# Altium schematic sheet AudioWayDSP-Codec.SchDoc
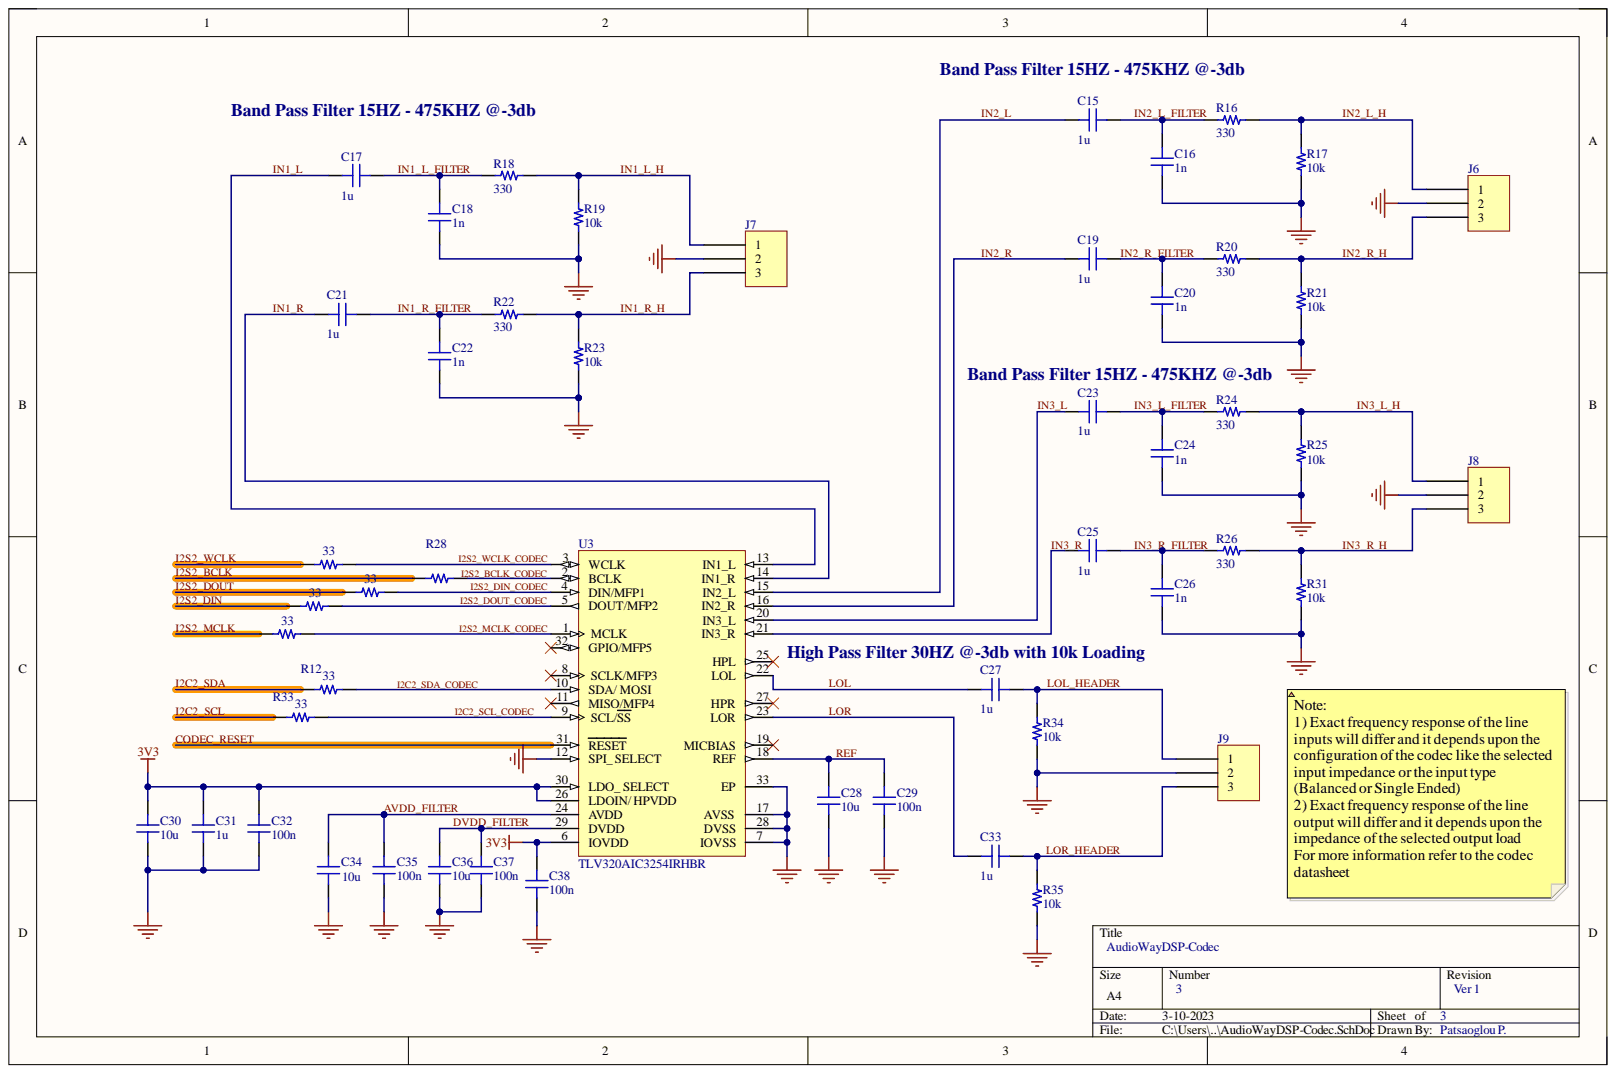
[diagram p0: AudioWayDSP-Codec.SchDoc]

## Parts
C15  1u  [CAP 0603_1608]
C16  1n  [CAP 0402_1005]
C17  1u  [CAP 0603_1608]
C18  1n  [CAP 0402_1005]
C19  1u  [CAP 0603_1608]
C20  1n  [CAP 0402_1005]
C21  1u  [CAP 0603_1608]
C22  1n  [CAP 0402_1005]
C23  1u  [CAP 0603_1608]
C24  1n  [CAP 0402_1005]
C25  1u  [CAP 0603_1608]
C26  1n  [CAP 0402_1005]
C27  1u  [CAP 0603_1608]
C28  10u  [CAP 0603_1608]
C29  100n  [CAP 0402_1005]
C30  10u  [CAP 0603_1608]
C31  1u  [CAP 0603_1608]
C32  100n  [CAP 0402_1005]
C33  1u  [CAP 0603_1608]
C34  10u  [CAP 0603_1608]
C35  100n  [CAP 0402_1005]
C36  10u  [CAP 0603_1608]
C37  100n  [CAP 0402_1005]
C38  100n  [CAP 0402_1005]
J6  B3B-XH-A(LF)(SN)  [JST XH B3B-XH-A]  (value not drawn)
J7  B3B-XH-A(LF)(SN)  [JST XH B3B-XH-A]  (value not drawn)
J8  B3B-XH-A(LF)(SN)  [JST XH B3B-XH-A]  (value not drawn)
J9  B3B-XH-A(LF)(SN)  [JST XH B3B-XH-A]  (value not drawn)
R12  33  [RES 0402_1005]
R16  330  [RES 0402_1005]
R17  10k  [RES 0402_1005]
R18  330  [RES 0402_1005]
R19  10k  [RES 0402_1005]
R20  330  [RES 0402_1005]
R21  10k  [RES 0402_1005]
R22  330  [RES 0402_1005]
R23  10k  [RES 0402_1005]
R24  330  [RES 0402_1005]
R25  10k  [RES 0402_1005]
R26  330  [RES 0402_1005]
R27  33  [RES 0402_1005]
R28  33  [RES 0402_1005]  (value not drawn)
R29  33  [RES 0402_1005]
R30  33  [RES 0402_1005]
R31  10k  [RES 0402_1005]
R32  33  [RES 0402_1005]
R33  33  [RES 0402_1005]
R34  10k  [RES 0402_1005]
R35  10k  [RES 0402_1005]
U3  TLV320AIC3254IRHBR  [RHB0032E_V]

## Nets
3V3: C30.2 C31.2 C32.2 C38.2 U3.6(IOVDD) U3.26(LDOIN/_HPVDD) U3.30(LDO__SELECT)
GND: C16.1 C18.1 C20.1 C22.1 C24.1 C26.1 C28.1 C29.1 C30.1 C31.1 C32.1 C34.1 C35.1 C36.1 C37.1 C38.1 J6.2 J7.2 J8.2 J9.2 R17.1 R19.1 R21.1 R23.1 R25.1 R31.1 R34.1 R35.1 U3.7(IOVSS) U3.12(SPI__SELECT) U3.17(AVSS) U3.28(DVSS) U3.33(EP)
AVDD_FILTER: C34.2 C35.2 U3.24(AVDD)
CODEC_RESET: U3.31(RESET)
DVDD_FILTER: C36.2 C37.2 U3.29(DVDD)
I2C2_SCL: R33.1
I2C2_SCL_CODEC: R33.2 U3.9(SCL/SS)
I2C2_SDA: R12.1
I2C2_SDA_CODEC: R12.2 U3.10(SDA/_MOSI)
I2S2_BCLK: R28.1
I2S2_BCLK_CODEC: R28.2 U3.2(BCLK)
I2S2_DIN: R30.1
I2S2_DIN_CODEC: R29.2 U3.4(DIN/MFP1)
I2S2_DOUT: R29.1
I2S2_DOUT_CODEC: R30.2 U3.5(DOUT/MFP2)
I2S2_MCLK: R32.1
I2S2_MCLK_CODEC: R32.2 U3.1(MCLK)
I2S2_WCLK: R27.1
I2S2_WCLK_CODEC: R27.2 U3.3(WCLK)
IN1_L: C17.2 U3.13(IN1_L)
IN1_L_FILTER: C17.1 C18.2 R18.2
IN1_L_H: J7.1 R18.1 R19.2
IN1_R: C21.2 U3.14(IN1_R)
IN1_R_FILTER: C21.1 C22.2 R22.2
IN1_R_H: J7.3 R22.1 R23.2
IN2_L: C15.2 U3.15(IN2_L)
IN2_L_FILTER: C15.1 C16.2 R16.2
IN2_L_H: J6.1 R16.1 R17.2
IN2_R: C19.2 U3.16(IN2_R)
IN2_R_FILTER: C19.1 C20.2 R20.2
IN2_R_H: J6.3 R20.1 R21.2
IN3_L: C23.2 U3.20(IN3_L)
IN3_L_FILTER: C23.1 C24.2 R24.2
IN3_L_H: J8.1 R24.1 R25.2
IN3_R: C25.2 U3.21(IN3_R)
IN3_R_FILTER: C25.1 C26.2 R26.2
IN3_R_H: J8.3 R26.1 R31.2
LOL: C27.2 U3.22(LOL)
LOL_HEADER: C27.1 J9.1 R34.2
LOR: C33.2 U3.23(LOR)
LOR_HEADER: C33.1 J9.3 R35.2
REF: C28.2 C29.2 U3.18(REF)

Not connected: U3 8(SCLK/MFP3) 11(MISO/MFP4) 19(MICBIAS) 25(HPL) 27(HPR) 32(GPIO/MFP5)

## Library data of the parts
C15 C17 C19 C21 C23 C25 C27 C31 C33 = 06035D105KAT2A: CAP CER 1UF 50V X5R 0603; manufacturer: AVX Corporation; Capacitance: 1µF; Database Table Name: Capacitors - Ceramic - 0603; Tolerance: ±10%; Applications: General Purpose; Component Kind: Standard; componentlink1description: Datasheet; componentlink1url: http://datasheets.avx.com/cx5r.pdf; componentlink2description: DigiKey Link; componentlink2url: http://digikey.com/product-detail/en/avx-corporation/06035D105KAT2A/478-10067-1-ND/6564289; Device Package: 0603 (1608 Metric); lastupdated: 02-Sep-20 1:03:26 AM; Library Name: Celestial Altium Library - altium_library.DbLib; Lifecycle Status: Active; Minimum Order: 1; Mounting Type: Surface Mount, MLCC; Operating Temperature: -55°C ~ 85°C; Package / Case: 0603 (1608 Metric); packaging: TapeAndReel; Part Number: 06035D105KAT2A; Part Status: Active; partid: 7730; price: 0.15; series: -; Size / Dimension: 0.063" L x 0.032" W (1.60mm x 0.81mm); Supplier 1: DigiKey; Supplier Part Number 1: 478-10067-1-ND; Temperature Coefficient: X5R; Thickness (Max): 0.035" (0.90mm); Value: 1u; Voltage - Rated: 50V
C16 C18 C20 C22 C24 C26 = C0402C102K5RECAUTO: CAP CER 0402 1NF 50V X7R 10%; manufacturer: KEMET; Capacitance: 1000 pF; Database Table Name: Capacitors - Ceramic - 0402; Applications: Automotive, ESD Protection; Component Kind: Standard; componentlink1description: Datasheet; componentlink1url: https://api.kemet.com/component-edge/download/datasheet/C0402C102K5RECAUTO.pdf; componentlink2description: DigiKey Link; componentlink2url: https://www.digikey.com/en/products/detail/kemet/C0402C102K5RECAUTO/8646471; Device Package: 0402 (1005 Metric); lastupdated: 01-Mar-23 8:03:25 PM; Library Name: Celestial Altium Library - altium_library.DbLib; Lifecycle Status: Active; Minimum Order: 1; Mounting Type: Surface Mount, MLCC; Operating Temperature: -55°C ~ 125°C; Package / Case: 0402 (1005 Metric); packaging: TapeAndReel; Part Number: C0402C102K5RECAUTO; Part Status: Active; partid: 38913; price: 0.01; Ratings: AEC-Q200; series: ESD SMD Auto X7R; Size / Dimension: 0.039" L x 0.020" W (1.00mm x 0.50mm); Supplier 1: DigiKey; Supplier Part Number 1: 399-C0402C102K5RECAUTOCT-ND; Temperature Coefficient: X7R; Thickness (Max): 0.022" (0.55mm); Tolerance: ±10%; Value: 1n; Voltage - Rated: 50V
C28 C30 C34 C36 = GRT188R61A106KE13D: CAP CER 10UF 10V X5R 0603; manufacturer: Murata Electronics; Capacitance: 10 µF; Database Table Name: Capacitors - Ceramic - 0603; Tolerance: ±10%; Applications: Automotive; Component Kind: Standard; componentlink1description: Datasheet; componentlink1url: https://search.murata.co.jp/Ceramy/image/img/A01X/G101/ENG/GRT188R61A106KE13-01.pdf; componentlink2description: DigiKey Link; componentlink2url: https://www.digikey.com/en/products/detail/murata-electronics/GRT188R61A106KE13D/5416733; Device Package: 0603 (1608 Metric); Features: Derating Recommended; lastupdated: 01-Mar-23 8:07:51 PM; Library Name: Celestial Altium Library - altium_library.DbLib; Lifecycle Status: Active; Minimum Order: 1; Mounting Type: Surface Mount, MLCC; Operating Temperature: -55°C ~ 85°C; Package / Case: 0603 (1608 Metric); packaging: TapeAndReel; Part Number: GRT188R61A106KE13D; Part Status: Active; partid: 2522; price: 0.06; Ratings: AEC-Q200; series: GRT; Size / Dimension: 0.063" L x 0.031" W (1.60mm x 0.80mm); Supplier 1: DigiKey; Supplier Part Number 1: 490-12311-1-ND; Temperature Coefficient: X5R; Thickness (Max): 0.037" (0.95mm); Value: 10u; Voltage - Rated: 10V
C29 C32 C35 C37 C38 = CGA2B3X5R1H104M050BB: CAP CER 0.1UF 50V X5R 0402; manufacturer: TDK Corporation; Capacitance: 0.1 µF; Database Table Name: Capacitors - Ceramic - 0402; Tolerance: ±20%; Applications: Automotive; Component Kind: Standard; componentlink1description: Datasheet; componentlink1url: https://product.tdk.com/info/en/catalog/datasheets/mlcc_automotive_general_en.pdf?ref_disty=digikey; componentlink2description: DigiKey Link; componentlink2url: https://www.digikey.com/en/products/detail/tdk-corporation/CGA2B3X5R1H104M050BB/3949450; Device Package: 0402 (1005 Metric); lastupdated: 01-Mar-23 8:04:14 PM; Library Name: Celestial Altium Library - altium_library.DbLib; Lifecycle Status: Active; Minimum Order: 1; Mounting Type: Surface Mount, MLCC; Operating Temperature: -55°C ~ 85°C; Package / Case: 0402 (1005 Metric); packaging: TapeAndReel; Part Number: CGA2B3X5R1H104M050BB; Part Status: Active; partid: 1792; price: 0.01; Ratings: AEC-Q200; series: CGA; Size / Dimension: 0.039" L x 0.020" W (1.00mm x 0.50mm); Supplier 1: DigiKey; Supplier Part Number 1: 445-12214-1-ND; Temperature Coefficient: X5R; Thickness (Max): 0.022" (0.55mm); Value: 100n; Voltage - Rated: 50V
J6-J9 = B3B-XH-A(LF)(SN): CONN HEADER VERT 3POS 2.5MM; Manufacturer: JST Sales America Inc.; Contact Length - Post: 0.134" (3.40mm); Current Rating (Amps): 3A; Database Table Name: Connectors - Rectangular Headers Male Pins; Material Flammability Rating: UL94 V-0; Number of Positions: 3; Number of Positions Loaded: All; Pitch - Mating: 0.098" (2.50mm); Shrouding: Shrouded - 4 Wall; Style: Board to Cable/Wire; Voltage Rating: 250V; Component Kind: Standard; ComponentLink1Description: Datasheet; ComponentLink1URL: http://www.jst-mfg.com/product/pdf/eng/eXH.pdf; ComponentLink2Description: DigiKey Link; ComponentLink2URL: http://digikey.com/product-detail/en/jst-sales-america-inc/B3B-XH-A-LF-SN/455-2248-ND/1651046; Connector Type: Header; Contact Finish - Mating: Tin; Contact Finish - Post: Tin; Contact Material: Brass; Contact Shape: Square; Contact Type: Male Pin; Fastening Type: Detent Lock; Insulation Color: Natural; Insulation Height: 0.276" (7.00mm); Insulation Material: Polyamide (PA66), Nylon 6/6; LastUpdated: 12-Jan-20 11:46:22 PM; Library Name: Celestial Altium Library - altium_library.DbLib; Lifecycle Status: Active; Minimum Order: 1; Mounting Type: Through Hole; Number of Rows: 1; Operating Temperature: -25°C ~ 85°C; Packaging: Bulk; Part Number: B3B-XH-A(LF)(SN); Part Status: Active; PartId: 68; Price: 0.19; Series: XH; Supplier 1: DigiKey; Supplier Part Number 1: 455-2248-ND; Termination: Press-Fit, Solder
R12 R27-R30 R32 R33 = CRCW040233R0FKEDC: RES 33 OHM 1% 1/16W 0402; Manufacturer: Vishay Dale; Database Table Name: Resistors - Surface Mount - 0402; Power (Watts): 0.063W, 1/16W; Resistance: 33 Ohms; Temperature Coefficient: ±100ppm/°C; Component Kind: Standard; ComponentLink1Description: Datasheet; ComponentLink1URL: http://www.vishay.com/docs/28773/crcwce3.pdf; ComponentLink2Description: DigiKey Link; ComponentLink2URL: https://www.digikey.com/en/products/detail/vishay-dale/CRCW040233R0FKEDC/7928390; Composition: Thick Film; Device Package: 0402 (1005 Metric); Height - Seated (Max): 0.014" (0.35mm); LastUpdated: 01-Mar-23 8:20:49 PM; Library Name: Celestial Altium Library - altium_library.DbLib; Lifecycle Status: Active; Minimum Order: 1; Number of Terminations: 2; Operating Temperature: -55°C ~ 155°C; Package / Case: 0402 (1005 Metric); Packaging: CutTape; Part Number: CRCW040233R0FKEDC; Part Status: Active; PartId: 12692; Price: 0.01; Series: CRCW-C; Size / Dimension: 0.039" L x 0.020" W (1.00mm x 0.50mm); Supplier 1: DigiKey; Supplier Device Package: 0402; Supplier Part Number 1: 541-3968-1-ND; Tolerance: ±1%; Value: 33
R16 R18 R20 R22 R24 R26 = AF0402FR-07330RL: RES SMD 330 OHM 1% 1/16W 0402; Manufacturer: Yageo; Database Table Name: Resistors - Surface Mount - 0402; Power (Watts): 0.063W, 1/16W; Resistance: 330 Ohms; Temperature Coefficient: ±100ppm/°C; Component Kind: Standard; ComponentLink1Description: Datasheet; ComponentLink1URL: https://www.yageo.com/upload/media/product/productsearch/datasheet/rchip/PYu-AF_51_RoHS_L_6.pdf; ComponentLink2Description: DigiKey Link; ComponentLink2URL: https://www.digikey.com/en/products/detail/yageo/AF0402FR-07330RL/5900541; Composition: Thick Film; Device Package: 0402 (1005 Metric); Features: Anti-Sulfur, Automotive AEC-Q200; Height - Seated (Max): 0.015" (0.37mm); LastUpdated: 01-Mar-23 8:19:51 PM; Library Name: Celestial Altium Library - altium_library.DbLib; Lifecycle Status: Active; Minimum Order: 1; Number of Terminations: 2; Operating Temperature: -55°C ~ 155°C; Package / Case: 0402 (1005 Metric); Packaging: TapeAndReel; Part Number: AF0402FR-07330RL; Part Status: Active; PartId: 65190; Price: 0.01; Ratings: AEC-Q200; Series: AF; Size / Dimension: 0.039" L x 0.020" W (1.00mm x 0.50mm); Supplier 1: DigiKey; Supplier Device Package: 0402; Supplier Part Number 1: 13-AF0402FR-07330RLCT-ND; Tolerance: ±1%; Value: 330
R17 R19 R21 R23 R25 R31 R34 R35 = RT0402FRE0710KL: RES SMD 10K OHM 1% 1/16W 0402; Manufacturer: Yageo; Database Table Name: Resistors - Surface Mount - 0402; Power (Watts): 0.063W, 1/16W; Resistance: 10 kOhms; Temperature Coefficient: ±50ppm/°C; Component Kind: Standard; ComponentLink1Description: Datasheet; ComponentLink1URL: https://www.yageo.com/upload/media/product/productsearch/datasheet/rchip/PYu-RT_1-to-0.01_RoHS_L_11.pdf; ComponentLink2Description: DigiKey Link; ComponentLink2URL: https://www.digikey.com/en/products/detail/yageo/RT0402FRE0710KL/1071709; Composition: Thin Film; Device Package: 0402 (1005 Metric); Height - Seated (Max): 0.014" (0.35mm); LastUpdated: 01-Mar-23 8:21:28 PM; Library Name: Celestial Altium Library - altium_library.DbLib; Lifecycle Status: Active; Minimum Order: 1; Number of Terminations: 2; Operating Temperature: -55°C ~ 155°C; Package / Case: 0402 (1005 Metric); Packaging: TapeAndReel; Part Number: RT0402FRE0710KL; Part Status: Active; PartId: 3186; Price: 0.01; Series: RT; Size / Dimension: 0.039" L x 0.020" W (1.00mm x 0.50mm); Supplier 1: DigiKey; Supplier Device Package: 0402; Supplier Part Number 1: YAG1233CT-ND; Tolerance: ±1%; Value: 10k
U3 = CMP-0331-00051-2: Very Low-Power Stereo Audio CODEC with miniDSP and Power TuneTM Technology, 2 ADCs and 2 DACs, 100 dB DAC SNR, -40 to 85 degC, 32-pin QFN (RHB), Green (RoHS & no Sb/Br); Manufacturer: Texas Instruments; # ADCs (typ): 2; Additional Features: MiniDSP, PowerTune (tm), LP Bypass, PLL, Digital Mic Support, LDO (for single supply operation up to 3.6V); Battery Powered: TRUE; Control Interface: SPI, I2C; DAC SNR: 100; Digital Audio Interfaces: L, R, I2S, TDM, DSP; Sampling Rate (Max) (kHz): 192; # DACs: 2; Code_JEDEC: MO-220; Component Kind: Standard; ComponentLink1Description: Datasheet; ComponentLink1URL: http://www.ti.com/general/docs/lit/getliterature.tsp?genericPartNumber=TLV320AIC3254&fileType=pdf; DatasheetVersion: SLAS549B; Headphone Driver: TRUE; Library Name: Altium Content Vault; Mounting Technology: Surface Mount; PackageDescription: 32-Pin Plastic Quad Flat No-Lead, 5 x 5 mm Body, 0.5 mm Pitch, 3.45 x 3.45 mm Exposed Pad; PackageReference: RHB0032E; PackageVersion: revD, Jun-2011; PartNumber: TLV320AIC3254IRHBR
